# Altium schematic sheet Buttons.SchDoc
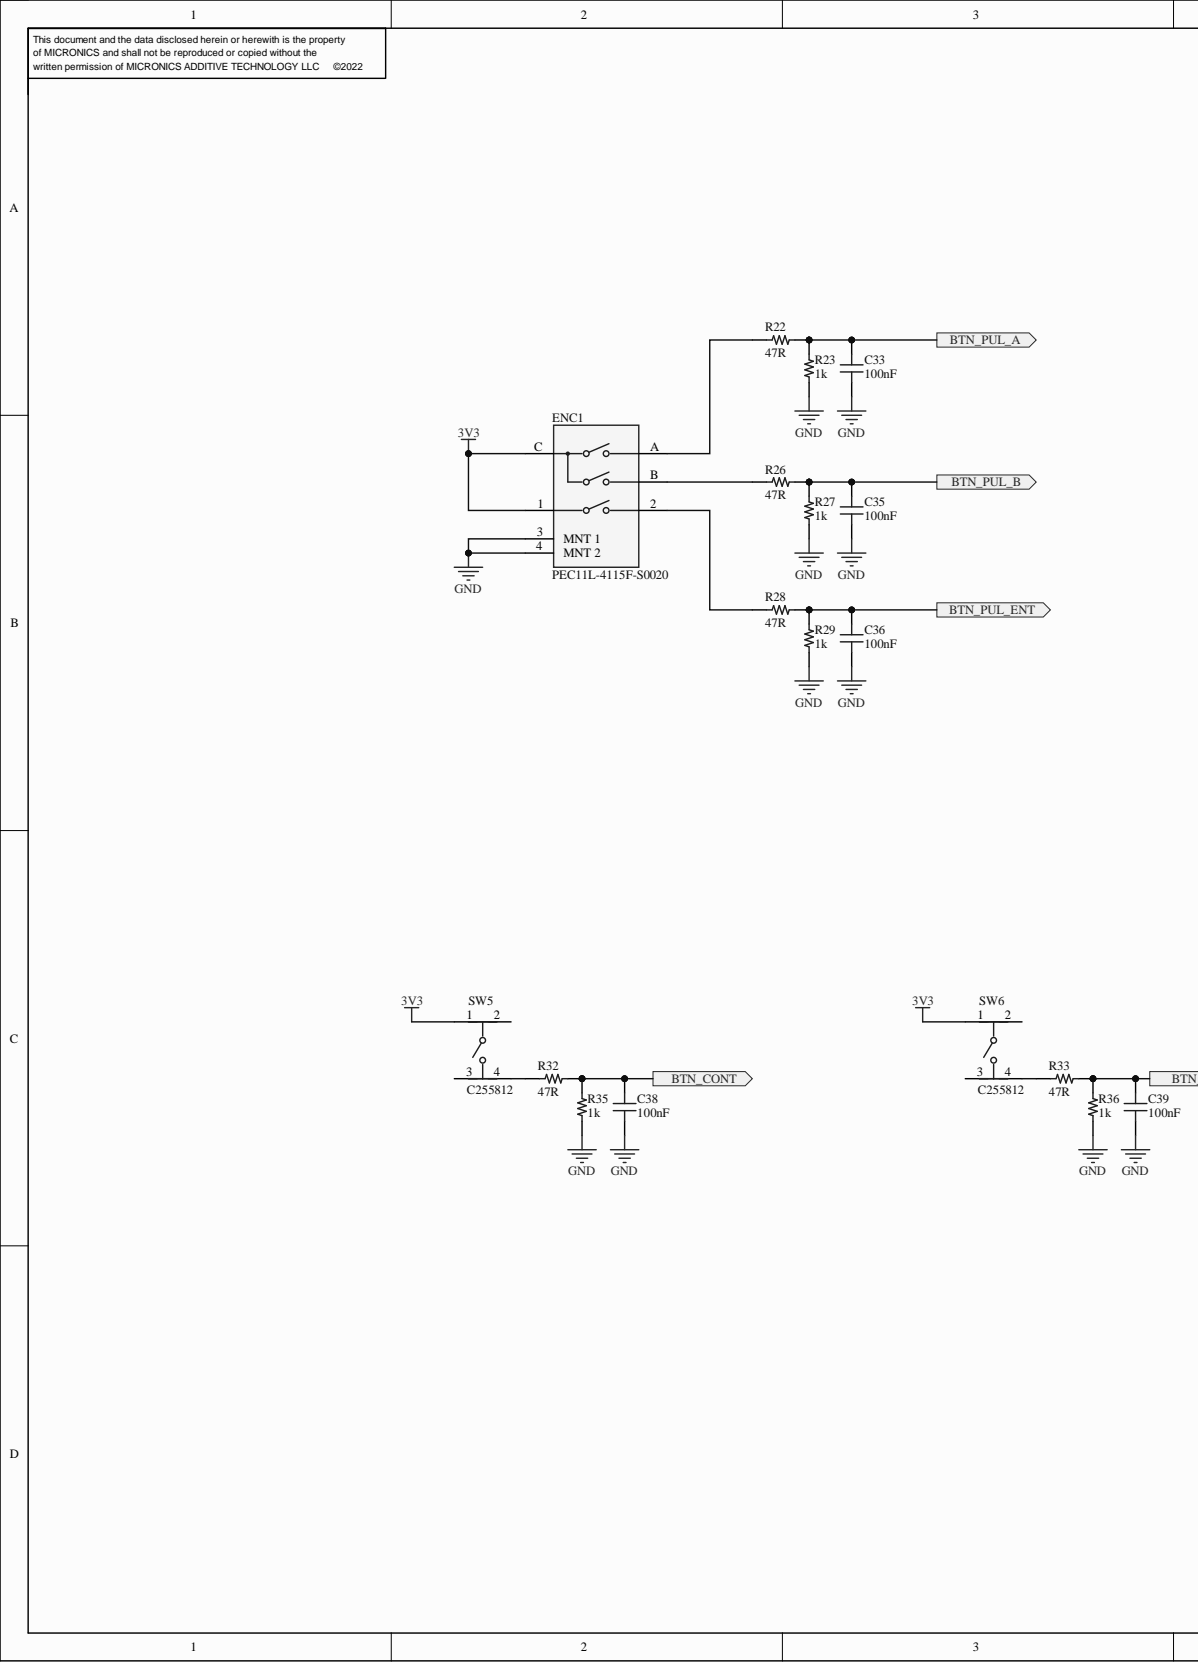
[diagram p0: Buttons.SchDoc, left]
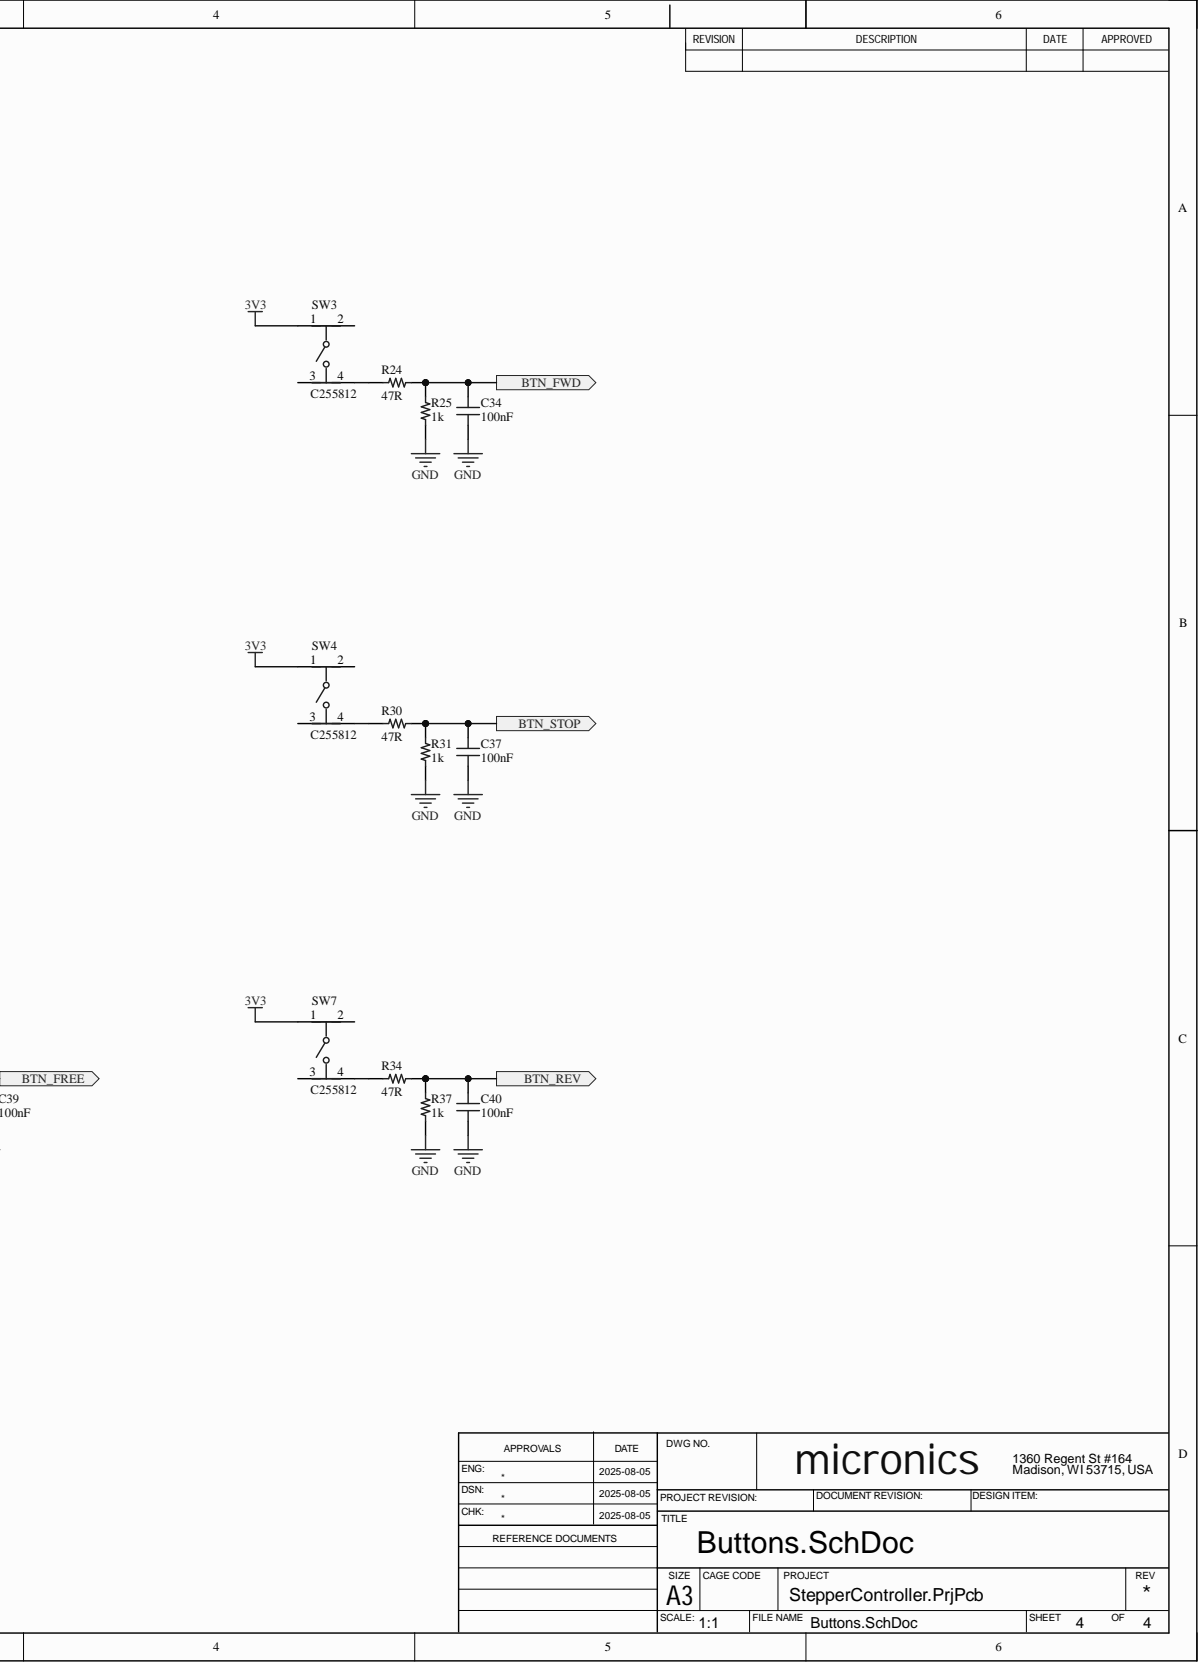
[diagram p1: Buttons.SchDoc, right]

## Parts
C33  100nF  [CAP 0603_1608]
C34  100nF  [CAP 0603_1608]
C35  100nF  [CAP 0603_1608]
C36  100nF  [CAP 0603_1608]
C37  100nF  [CAP 0603_1608]
C38  100nF  [CAP 0603_1608]
C39  100nF  [CAP 0603_1608]
C40  100nF  [CAP 0603_1608]
ENC1  PEC11L-4115F-S0020  [FP-PEC11L-4115F-S0020-MFG]
R22  47R  [RES 0603_1608]
R23  1k  [RES 0603_1608]
R24  47R  [RES 0603_1608]
R25  1k  [RES 0603_1608]
R26  47R  [RES 0603_1608]
R27  1k  [RES 0603_1608]
R28  47R  [RES 0603_1608]
R29  1k  [RES 0603_1608]
R30  47R  [RES 0603_1608]
R31  1k  [RES 0603_1608]
R32  47R  [RES 0603_1608]
R33  47R  [RES 0603_1608]
R34  47R  [RES 0603_1608]
R35  1k  [RES 0603_1608]
R36  1k  [RES 0603_1608]
R37  1k  [RES 0603_1608]
SW3  C255812  [FP-FSM2JSMAA-MFG]
SW4  C255812  [FP-FSM2JSMAA-MFG]
SW5  C255812  [FP-FSM2JSMAA-MFG]
SW6  C255812  [FP-FSM2JSMAA-MFG]
SW7  C255812  [FP-FSM2JSMAA-MFG]

## Ports
BTN_CONT, BTN_FREE, BTN_FWD, BTN_PUL_A, BTN_PUL_B, BTN_PUL_ENT, BTN_REV, BTN_STOP

## Nets
3V3: ENC1.1 ENC1.C SW3.1 SW4.1 SW5.1 SW6.1 SW7.1
GND: C33.2 C34.2 C35.2 C36.2 C37.2 C38.2 C39.2 C40.2 ENC1.3(MNT_1) ENC1.4(MNT_2) R23.2 R25.2 R27.2 R29.2 R31.2 R35.2 R36.2 R37.2
BTN_CONT: C38.1 R32.2 R35.1 ; port BTN_CONT
BTN_FREE: C39.1 R33.2 R36.1 ; port BTN_FREE
BTN_FWD: C34.1 R24.2 R25.1 ; port BTN_FWD
BTN_PUL_A: C33.1 R22.2 R23.1 ; port BTN_PUL_A
BTN_PUL_B: C35.1 R26.2 R27.1 ; port BTN_PUL_B
BTN_PUL_ENT: C36.1 R28.2 R29.1 ; port BTN_PUL_ENT
BTN_REV: C40.1 R34.2 R37.1 ; port BTN_REV
BTN_STOP: C37.1 R30.2 R31.1 ; port BTN_STOP
NetENC1_2: ENC1.2 R28.1
NetENC1_A: ENC1.A R22.1
NetENC1_B: ENC1.B R26.1
NetR24_1: R24.1 SW3.4
NetR30_1: R30.1 SW4.4
NetR32_1: R32.1 SW5.4
NetR33_1: R33.1 SW6.4
NetR34_1: R34.1 SW7.4

Not connected: SW3 2 3; SW4 2 3; SW5 2 3; SW6 2 3; SW7 2 3

## Library data of the parts
C33-C40 = 250X14W223MV4T: C14663; manufacturer: Johanson Dielectrics Inc.; Database Table Name: Capacitors - Ceramic - 0603; Temperature Coefficient: X7R; Tolerance: ±20%; Voltage - Rated: 25V; Applications: Bypass, Decoupling; Capacitance: 100nF; Component Kind: Standard; componentlink1description: Datasheet; componentlink1url: https://www.johansondielectrics.com/downloads/x2y-filter-and-decoupling-capacitors.pdf; componentlink2description: DigiKey Link; componentlink2url: https://www.digikey.com/en/products/detail/johanson-dielectrics-inc/250X14W223MV4T/1628740; Device Package: 0603 (1608 Metric); Features: Low ESL (X2Y); lastupdated: 2022-05-16 11:25:57 AM; Library Name: Celestial Altium Library - altium_library.DbLib; Lifecycle Status: Active; Minimum Order: 1; Mounting Type: Surface Mount, MLCC; Operating Temperature: -55°C ~ 125°C; Package / Case: 0603 (1608 Metric); packaging: TapeAndReel; Part Number: 250X14W223MV4T; Part Status: Active; partid: 14325; price: 0.07; series: X2Y®; Size / Dimension: 0.064" L x 0.035" W (1.63mm x 0.89mm); Supplier 1: DigiKey; Supplier Part Number 1: 709-1087-1-ND; Thickness (Max): 0.026" (0.66mm); Value: 100nF
ENC1 = CMP-07421-000053-1: ROTARY ENCODER MECHANICAL 20PPR; Built in Switch: Yes; Number of Channels: 2; Output Type: Quadrature; Pulses per Revolution: 20; Component Kind: Standard; Detent: Yes; Encoder Type: Mechanical; Height: 12mm; Length: 19.5mm; Library Name: Altium Content Vault; Max Operating Temperature: 80°C; Min Operating Temperature: -25°C; Mount: Through Hole; Orientation: Vertical; Package Quantity: 75; Packaging: Bulk; RoHS Compliant: Yes; Series: PEC11; Termination: PC Pin; Width: 11.8mm
R22-R37 = RNCF0603DTE23K2: RES 1% 0603; Manufacturer: Stackpole Electronics Inc; Database Table Name: Resistors - Surface Mount - 0603; Power (Watts): 0.1W, 1/10W; Temperature Coefficient: ±25ppm/°C; Tolerance: ±0.5%; Component Kind: Standard; ComponentLink1Description: Datasheet; ComponentLink1URL: https://www.seielect.com/catalog/sei-rncf.pdf; ComponentLink2Description: DigiKey Link; ComponentLink2URL: https://www.digikey.com/en/products/detail/stackpole-electronics-inc/RNCF0603DTE23K2/6264115; Composition: Thin Film; Device Package: 0603 (1608 Metric); Features: Automotive AEC-Q200; Height - Seated (Max): 0.022" (0.55mm); LastUpdated: 2022-05-20 3:01:33 PM; Library Name: Celestial Altium Library - altium_library.DbLib; Lifecycle Status: Active; Minimum Order: 1; Number of Terminations: 2; Operating Temperature: -55°C ~ 155°C; Package / Case: 0603 (1608 Metric); Packaging: TapeAndReel; Part Number: RNCF0603DTE23K2; Part Status: Active; PartId: 5426; Price: 0.01; Ratings: AEC-Q200; Series: RNCF; Size / Dimension: 0.061" L x 0.031" W (1.55mm x 0.80mm); Supplier 1: DigiKey; Supplier Device Package: 0603; Supplier Part Number 1: RNCF0603DTE23K2CT-ND
  R22 R24 R26 R28 R30 R32-R34: Resistance: 47R; Value: 47R
  R23 R25 R27 R29 R31 R35-R37: Resistance: 1k; Value: 1k
SW3-SW7 = CMP-03402-000003-1: SWITCH TACTILE SPST-NO 0.05A 24V; Manufacturer: TE Connectivity; Manufacturer Part Number: FSM2JSMAA; Contact Current Rating: 50mA; Contact Resistance: 100mΩ; Electromechanical Life: 100000Cycles; Operating Force: 260gf; Standoff Height: 0.05mm; Automotive: No; Category: Switch; Component Kind: Standard; ComponentLink2Description: Datasheet; ComponentLink2URL: https://www.te.com/commerce/DocumentDelivery/DDEController?Action=showdoc&DocId=Customer+Drawing%7F2-1437565-7%7FV%7Fpdf%7FEnglish%7FENG_CD_2-1437565-7_V.pdf%7F2-1437565-8; Device Class L1: Electromechanical; Device Class L2: Switches; Device Class L3: Pushbutton Switches; Digikey Description: SWITCH TACTILE SPST-NO 0.05A 24V; Height: 4.59mm; Lead Free: Yes; Library Name: Altium Content Vault; Mount: Surface Mount; Mouser Description: Tactile Switches TACTILE 260G FORCE S; Octopart: https://octopart.com/fsm2jsmaa-te+connectivity-41720620; Package: 0; RoHS: TRUE; Temperature: -35°C to +85°C; Temperature Range High: +85°C; Temperature Range Low: -35°C; Throw Configuration: SPST-NO; Voltage Rating Dc: 24V
